# Altium schematic sheet mbedXdotShield-V_1_2_0_4.SchDoc(mbedXdotShield-V_1_2_0_4)
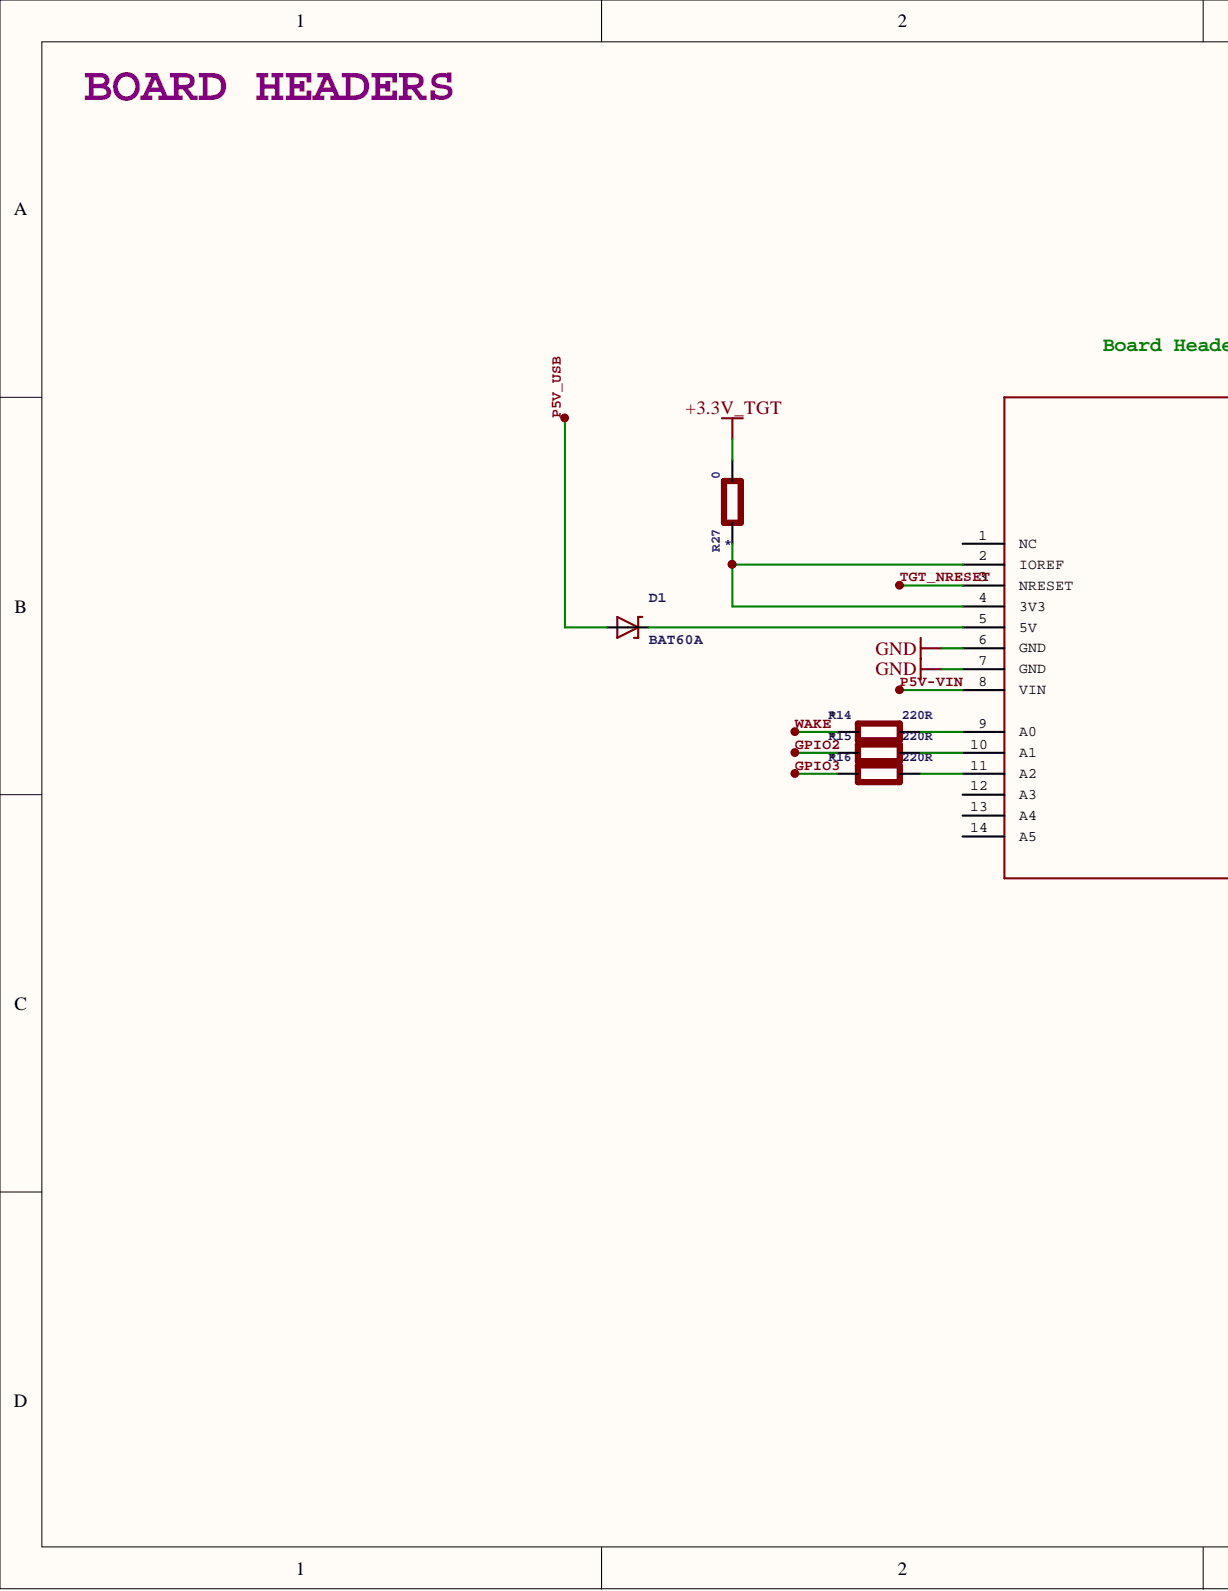
[diagram p0: mbedXdotShield-V_1_2_0_4.SchDoc, left]
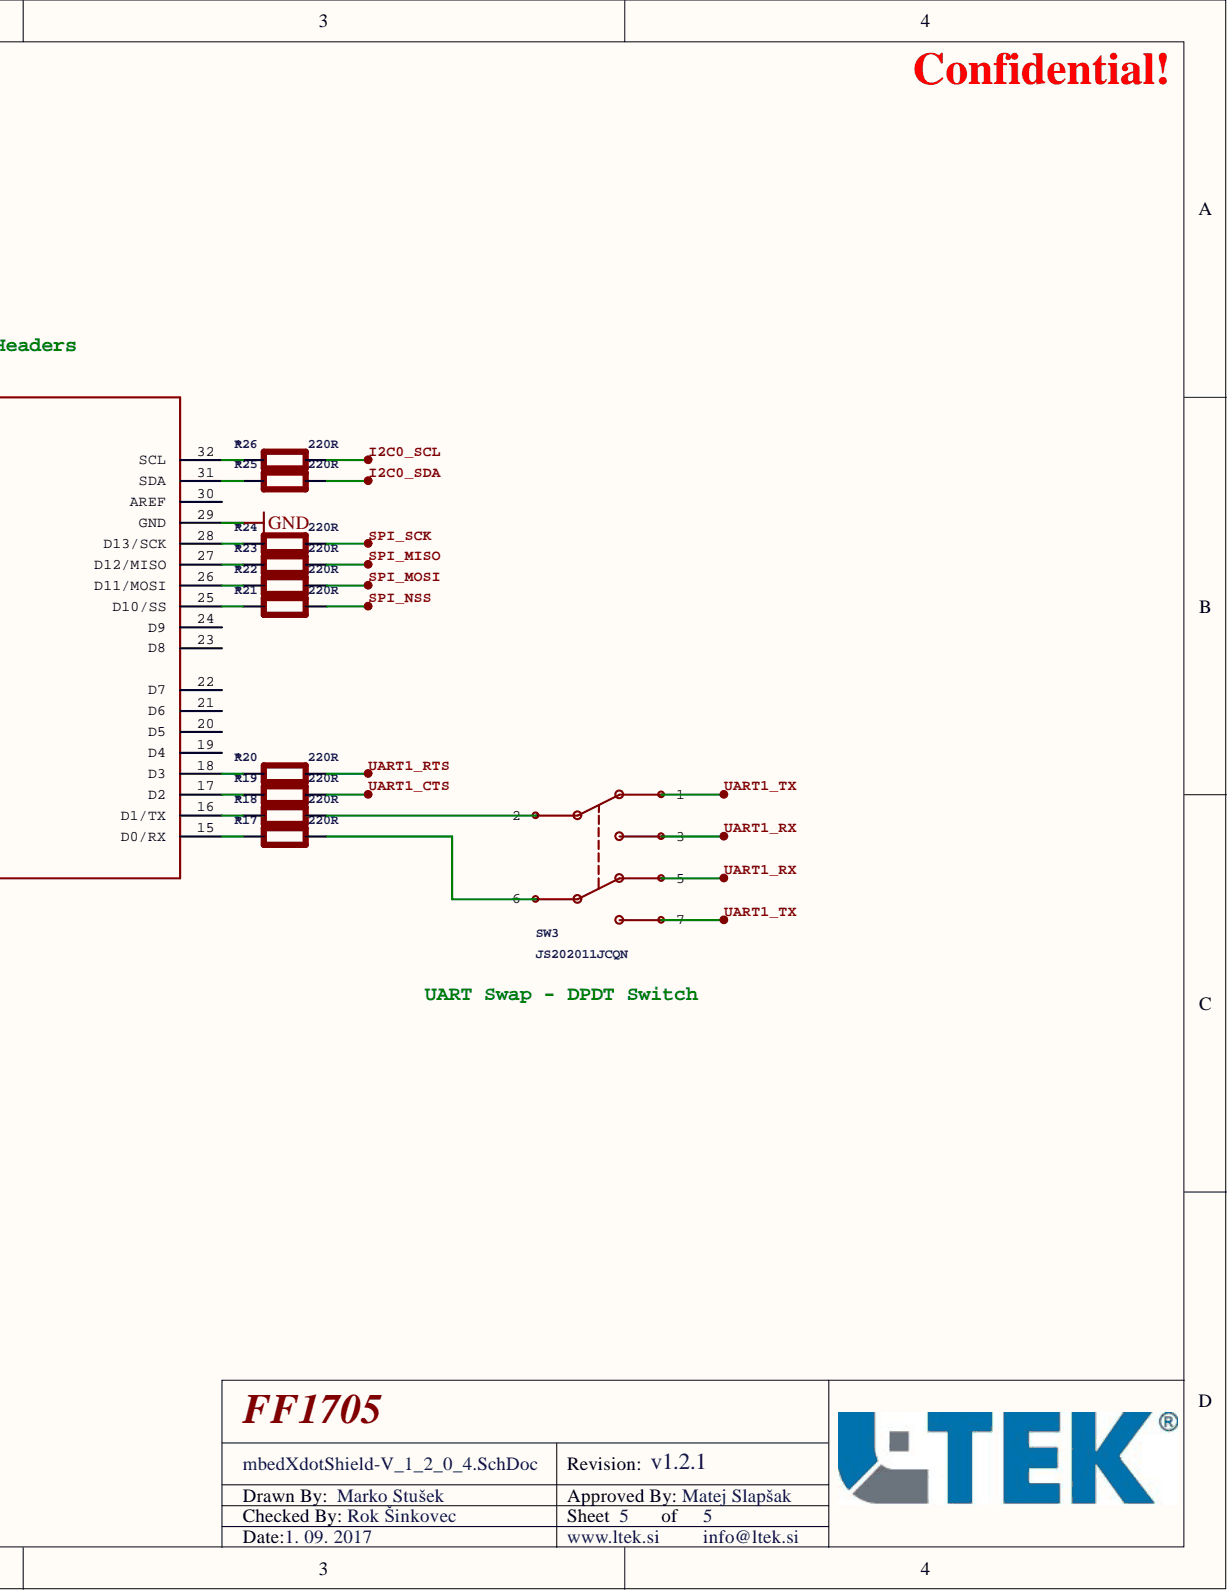
[diagram p1: mbedXdotShield-V_1_2_0_4.SchDoc, right]

## Parts
BRD1  K64F/K22F-SHIELD  [K64F/K22F]  (value not drawn)  (no BOM)
D1  BAT60A  [SOD323]
R14  220R  [0402]
R15  220R  [0402]
R16  220R  [0402]
R17  220R  [0402]
R18  220R  [0402]
R19  220R  [0402]
R20  220R  [0402]
R21  220R  [0402]
R22  220R  [0402]
R23  220R  [0402]
R24  220R  [0402]
R25  220R  [0402]
R26  220R  [0402]
R27  0  [0402]
SW3  JS202011JCQN  [JS202011JCQN]

## Nets
+3.3V_TGT: R27.2
GND: BRD1.6(GND) BRD1.7(GND) BRD1.29(GND)
GPIO2: R15.1
GPIO3: R16.1
I2C0_SCL: R26.2
I2C0_SDA: R25.2
P5V-VIN: BRD1.8(VIN)
P5V_USB: D1.A
SPI_MISO: R23.2
SPI_MOSI: R22.2
SPI_NSS: R21.2
SPI_SCK: R24.2
TGT_NRESET: BRD1.3(NRESET)
UART1_CTS: R19.2
UART1_RTS: R20.2
UART1_RX: SW3.3 SW3.5
UART1_TX: SW3.1 SW3.7
WAKE: R14.1
NetBRD1_2: BRD1.2(IOREF) BRD1.4(3V3) R27.1
NetBRD1_5: BRD1.5(5V) D1.K
NetBRD1_9: BRD1.9(A0) R14.2
NetBRD1_10: BRD1.10(A1) R15.2
NetBRD1_11: BRD1.11(A2) R16.2
NetBRD1_15: BRD1.15(D0/RX) R17.1
NetBRD1_16: BRD1.16(D1/TX) R18.1
NetBRD1_17: BRD1.17(D2) R19.1
NetBRD1_18: BRD1.18(D3) R20.1
NetBRD1_25: BRD1.25(D10/SS) R21.1
NetBRD1_26: BRD1.26(D11/MOSI) R22.1
NetBRD1_27: BRD1.27(D12/MISO) R23.1
NetBRD1_28: BRD1.28(D13/SCK) R24.1
NetBRD1_31: BRD1.31(SDA) R25.1
NetBRD1_32: BRD1.32(SCL) R26.1
NetR17_2: R17.2 SW3.6
NetR18_2: R18.2 SW3.2

Not connected: BRD1 1(NC) 12(A3) 13(A4) 14(A5) 19(D4) 20(D5) 21(D6) 22(D7) 23(D8) 24(D9) 30(AREF)

## Library data of the parts
BRD1 = K64F/K22F-SHIELD: Shield for K64F/K22F; Library Name: mbed-HDK-misc-Board.IntLib
D1 = BAT60A: SCHOTTKY DIODE; MFN: BAT60AE6327HTSA1; MF: Infineon; OC-NEWARK: 85X4127; OC-MOUSER: 726-BAT60AE6327; OC-DIGIKEY: BAT60AE6327HTSA1CT-ND; Component Kind: Standard; Library Name: mbed-HDK-Transistor.IntLib
R14-R27 = RESISTOR0402: Resistor; Component Kind: Standard; Library Name: mbed-HDK-RLCQ.IntLib
SW3 = JS202011JCQN: SLIDE TOGGLE SWITCH VERTICAL (DPDT); MF: C&K Components; OC-MOUSER: 611-JS202011JCQN; OC-DIGIKEY: CKN10723CT-ND; MFN: JS202011JCQN; Component Kind: Standard; Library Name: mbed-HDK-Switch.IntLib
